# Altium schematic sheet InterfaceBoard.SchDoc
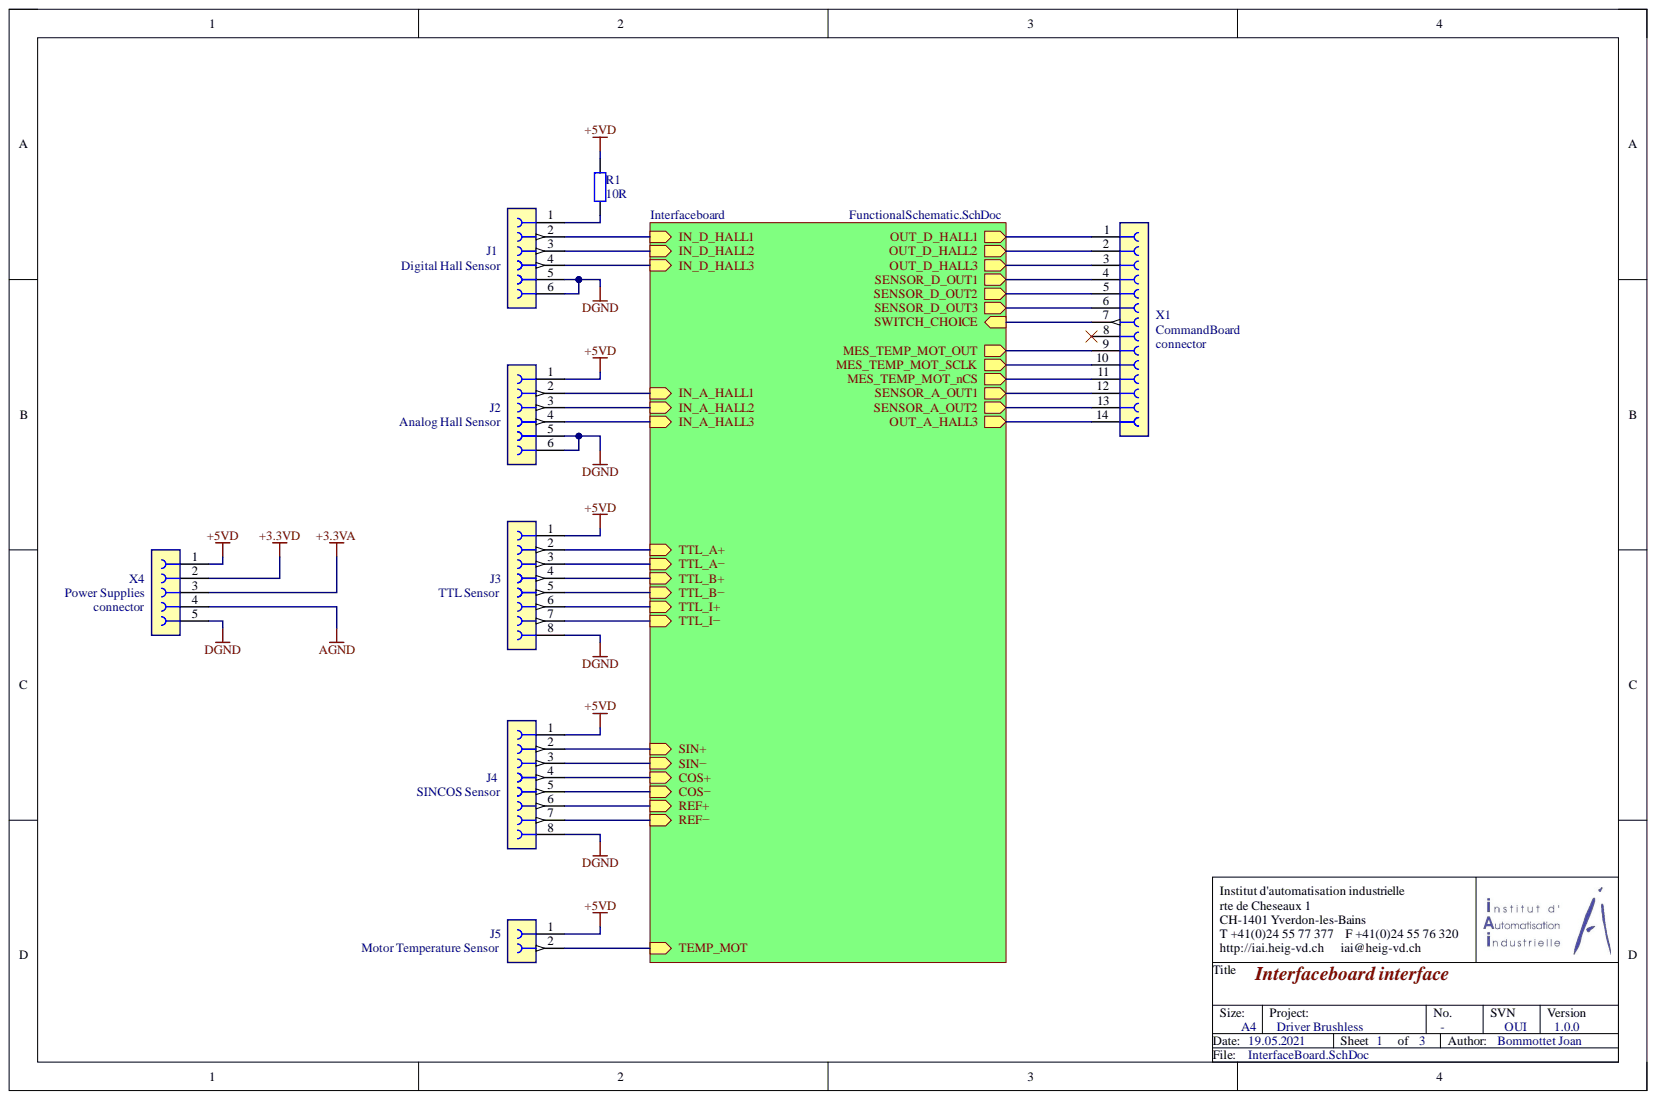
[diagram p0: InterfaceBoard.SchDoc]

## Parts
J1  CONNECT SMD 6pos 180°  [TE_7-215079-6]  (value not drawn)
J2  CONNECT SMD 6pos 180°  [TE_7-215079-6]  (value not drawn)
J3  CONNECT SMD 8pos 180°  [TE_7-215079-8]  (value not drawn)
J4  CONNECT SMD 8pos 180°  [TE_7-215079-8]  (value not drawn)
J5  CONNECT 2pos 90°  [62000611821 - 2pin]  (value not drawn)
R1  value 10R  [RESC1508X04N]  (hidden comment: RES SMD 10R 0603)
X1  system: connector  Use: CommandBoard  [62201421821]  (hidden comment: CONNECT SMD 16pos 180°)
X4  system: connector  [62000611821 - 5pin]  (hidden comment: CONNECT HEADER 5pos 180°)

## Nets
+3.3VA: X4.3
+3.3VD: X4.2
+5VD: J2.1 J3.1 J4.1 J5.1 R1.2 X4.1
AGND: X4.4
DGND: J1.5 J1.6 J2.5 J2.6 J3.8 J4.8 X4.5
NetJ1_1: J1.1 R1.1
NetJ1_2: J1.2
NetJ1_3: J1.3
NetJ1_4: J1.4
NetJ2_2: J2.2
NetJ2_3: J2.3
NetJ2_4: J2.4
NetJ3_2: J3.2
NetJ3_3: J3.3
NetJ3_4: J3.4
NetJ3_5: J3.5
NetJ3_6: J3.6
NetJ3_7: J3.7
NetJ4_2: J4.2
NetJ4_3: J4.3
NetJ4_4: J4.4
NetJ4_5: J4.5
NetJ4_6: J4.6
NetJ4_7: J4.7
NetJ5_2: J5.2
NetX1_1: X1.1
NetX1_2: X1.2
NetX1_3: X1.3
NetX1_4: X1.4
NetX1_5: X1.5
NetX1_6: X1.6
NetX1_7: X1.7
NetX1_8: X1.8
NetX1_9: X1.9
NetX1_10: X1.10
NetX1_11: X1.11
NetX1_12: X1.12
NetX1_13: X1.13
NetX1_14: X1.14

## Library data of the parts
J1 = CONNECT SMD 6pos 180° 2.54mm: Use: Digital Hall Sensor; Component Kind: Standard; Library Name: InterfaceBoard.SchLib
J2 = CONNECT SMD 6pos 180° 2.54mm: Use: Analog Hall Sensor; Component Kind: Standard; Library Name: InterfaceBoard.SchLib
J3 = CONNECT SMD 8pos 180° 2.54mm: Use: TTL Sensor; Component Kind: Standard; Library Name: InterfaceBoard.SchLib
J4 = CONNECT SMD 8pos 180° 2.54mm: Use: SINCOS Sensor; Component Kind: Standard; Library Name: InterfaceBoard.SchLib
J5 = CONNECT 2pos 90° 3.81mm: 2 Positions, bloc de jonction Embase, broche mâle, gainée (4 côtés) 0,150 po (3,81mm) 90°, engle droit Trou traversant; Use: Motor Temperature Sensor; Value: WR-TBL322; Component Kind: Standard; Library Name: InterfaceBoard.SchLib; Supplier 1: Digi-Key; Supplier Part Number 1: 732-2088-ND
R1 = RES SMD 10 OHM 1% 1/10W 0603: 10 Ohms ±1% 0,1W, 1/10W Pavé résistif 0603 (1608 métrique) AutomobileAEC-Q200 Couche épaisse; Component Kind: Standard; Library Name: InterfaceBoard.SchLib; Supplier 1: Digi-Key; Supplier Part Number 1: RMCF0603FT10R0CT-ND
X1 = CONNECT SMD 16pos 180° 2.54mm: Component Kind: Standard; Library Name: InterfaceBoard.SchLib
X4 = CONNECT HEADER 5pos 180° 2mm: Use: Power Supplies; Component Kind: Standard; Library Name: InterfaceBoard.SchLib
